# Altium schematic sheet Mechanical-Keyboard.SchDoc
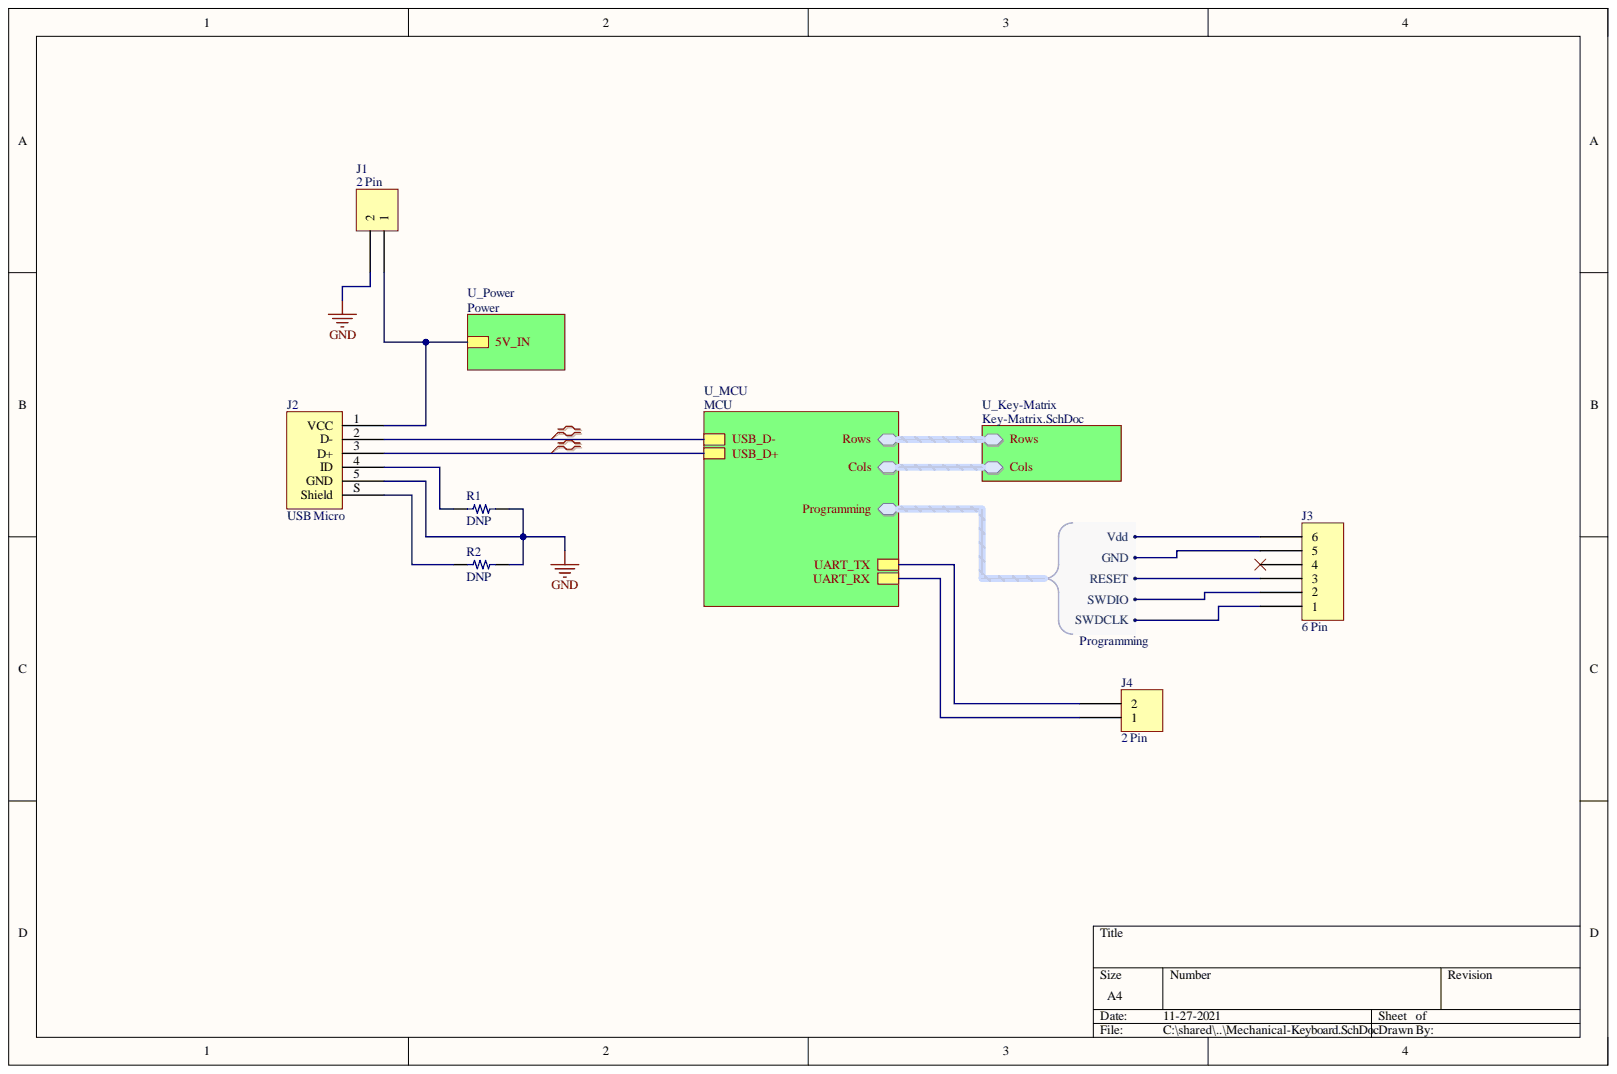
[diagram p0: Mechanical-Keyboard.SchDoc]

## Parts
J1  2 Pin  [HDR1X2]
J2  USB Micro  [FCI USB 10033526-N3212LF]
J3  6 Pin  [HDR1X6]
J4  2 Pin  [HDR1X2]
R1  DNP  [RES 0603_1608]
R2  DNP  [RES 0603_1608]

## Nets
GND: J1.2 J2.5(GND) R1.2 R2.2
NetJ1_1: J1.1 J2.1(VCC)
NetJ2_2: J2.2(D-)
NetJ2_3: J2.3(D+)
NetJ2_4: J2.4(ID) R1.1
NetJ2_S: J2.S(Shield) R2.1
NetJ3_1: J3.1
NetJ3_2: J3.2
NetJ3_3: J3.3
NetJ3_4: J3.4
NetJ3_5: J3.5
NetJ3_6: J3.6
NetJ4_1: J4.1
NetJ4_2: J4.2
Cols.Col0:
Cols.Col1:
Cols.Col2:
Cols.Col3:
Rows.Row0:
Rows.Row1:
Rows.Row2:
Rows.Row3:
Rows.Row4:

## Library data of the parts
J2 = USB 2.0 Type B Micro: Component Kind: Standard; Library Name: SCH - CONNECTORS - USB 2.0 TYPE B MICRO.SCHLIB
R1 R2 = Resistor: SMT Resistor; Component Kind: Standard; Library Name: SCH - PASSIVES - RESISTOR.SCHLIB
J1 J4 = 2 Pin: Component Kind: Standard; Library Name: SCH - CONNECTORS - 2 PIN.SCHLIB
J3 = 6 Pin: Component Kind: Standard; Library Name: SCH - CONNECTORS - 6 PIN.SCHLIB
